# Altium schematic sheet MCS_diag_CAN.SchDoc(MCS_diag_CAN)
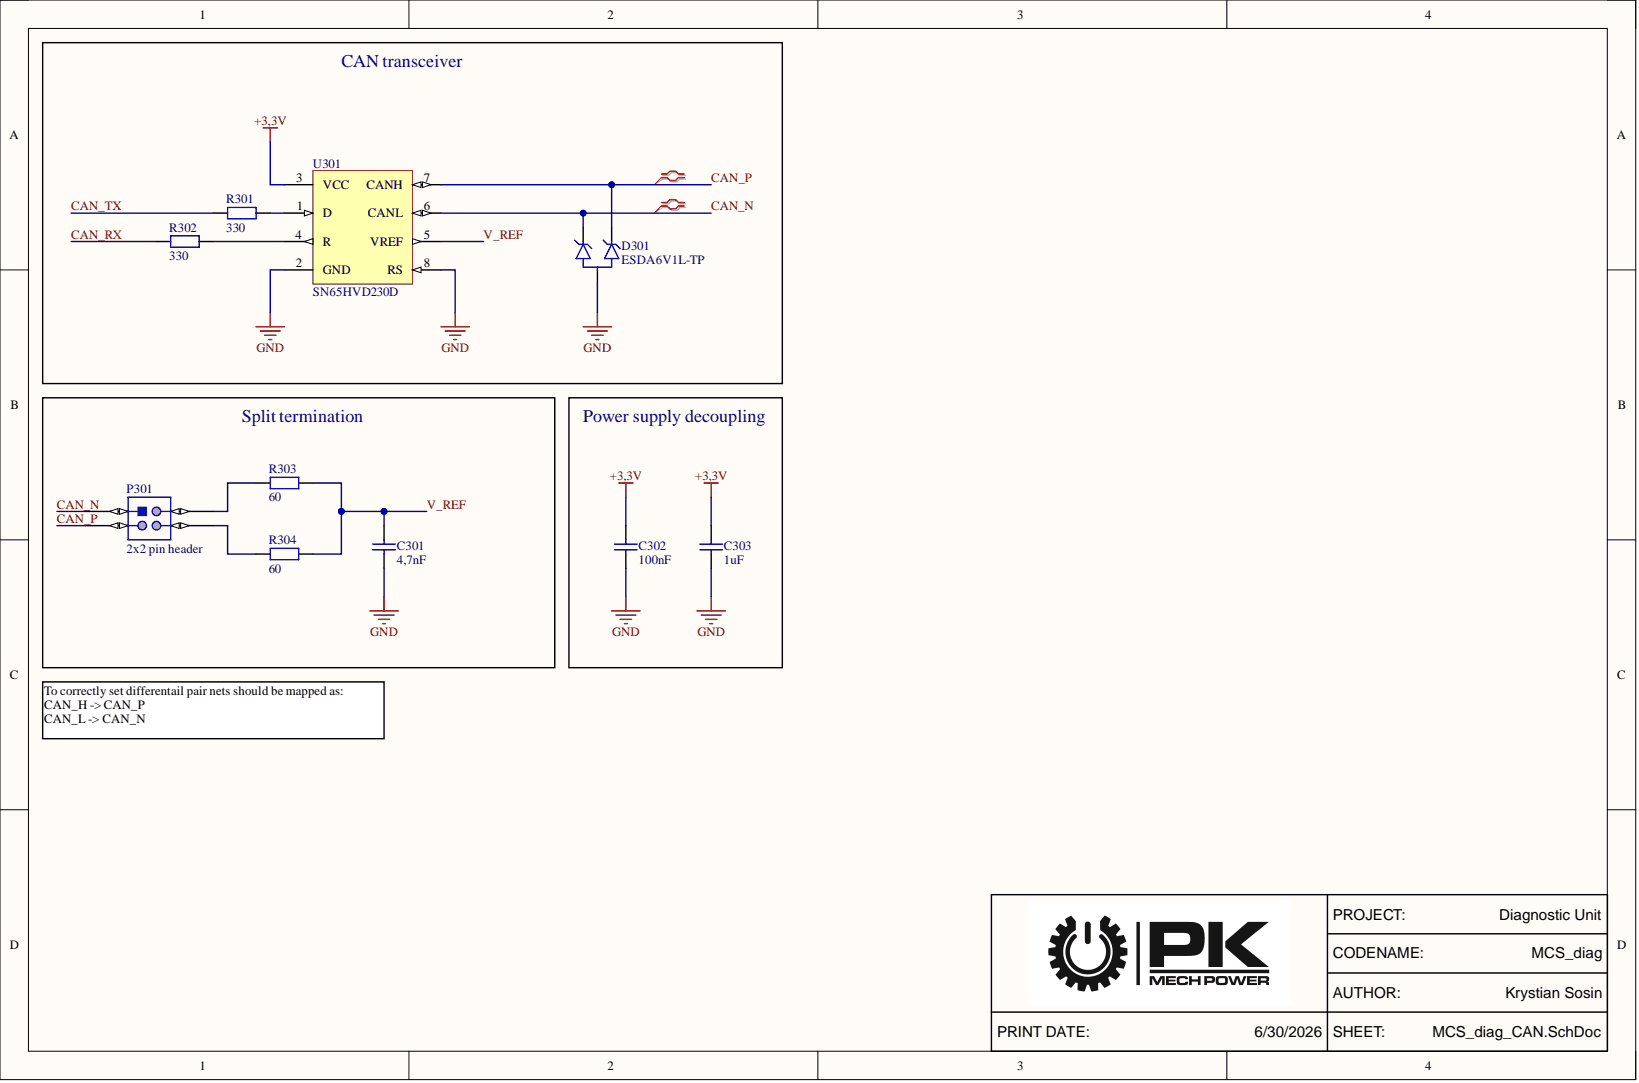
[diagram p0: MCS_diag_CAN.SchDoc]

## Parts
C301  value 4,7nF  (hidden comment: Capacitor 0603)
C302  value 100nF  (hidden comment: Capacitor 0603)
C303  value 1uF  (hidden comment: Capacitor 0603)
D301  ESDA6V1L-TP
P301  2x2 pin header
R301  value 330  (hidden comment: Resistor 0603)
R302  value 330  (hidden comment: Resistor 0603)
R303  value 60  (hidden comment: Resistor 0603)
R304  value 60  (hidden comment: Resistor 0603)
U301  SN65HVD230D

## Nets
+3,3V: C302.2 C303.2 U301.3(VCC)
GND: C301.1 C302.1 C303.1 D301.3 U301.2(GND) U301.8(RS)
CAN_N: D301.2 P301.1 U301.6(CANL)
CAN_P: D301.1 P301.3 U301.7(CANH)
CAN_RX: R302.1
CAN_TX: R301.1
V_REF: C301.2 R303.1 R304.2 U301.5(VREF)
NetP301_2: P301.2 R303.2
NetP301_4: P301.4 R304.1
NetR301_2: R301.2 U301.1(D)
NetR302_2: R302.2 U301.4(R)

## Library data of the parts
C301-C303 = CMP-001-00008-4: SMD 0603 capacitor; Component Kind: Standard; Library Name: EMEA- PK MechPower-  Cracow University of Technology
D301 = CMP-004-00000-2: ESD Suppressor Diode TVS Uni-Dir 5.25V 3-Pin SOT-23 T/R; Component Kind: Standard; Library Name: EMEA- PK MechPower-  Cracow University of Technology
P301 = CMP-001-0000-10: Double row 2 pin header; Component Kind: Standard; Library Name: EMEA- PK MechPower-  Cracow University of Technology
R301-R304 = CMP-009-00010-5: SMD 0603 resistor; Component Kind: Standard; Library Name: EMEA- PK MechPower-  Cracow University of Technology
U301 = CMP-015-00000-3: SN65HVD230 3.3 V CAN transceiver; Component Kind: Standard; Library Name: EMEA- PK MechPower-  Cracow University of Technology
